# Altium schematic sheet dc_dc_converter.SchDoc
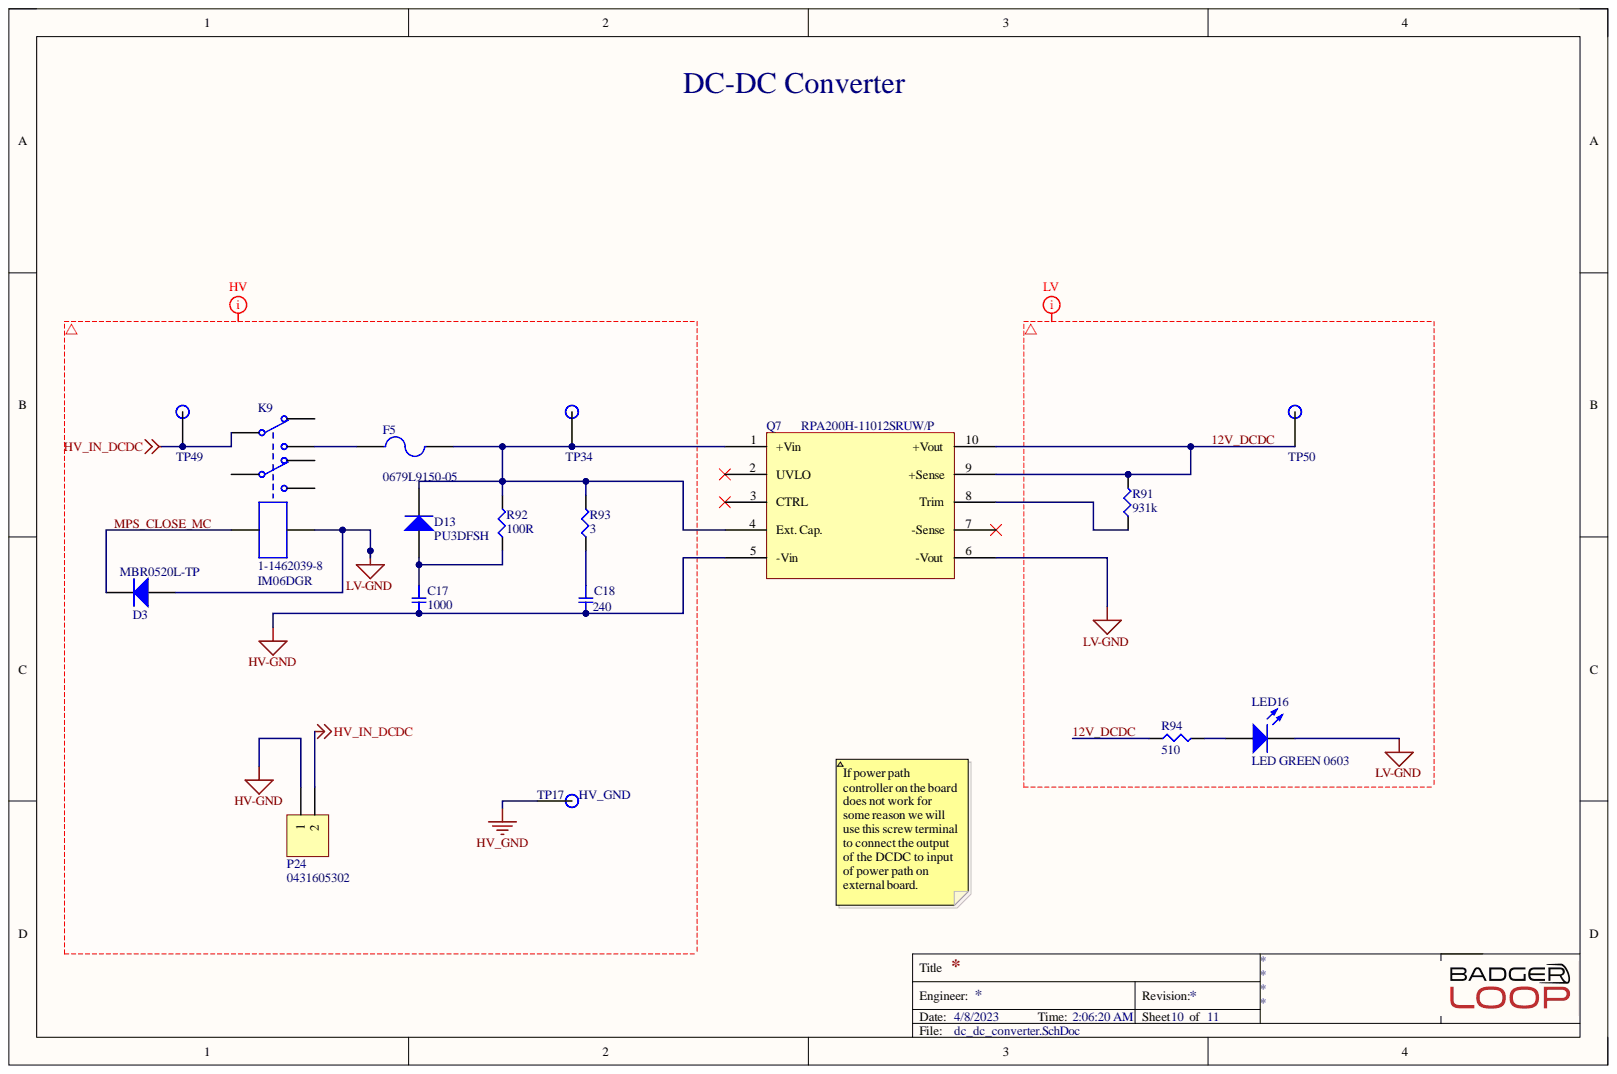
[diagram p0: dc_dc_converter.SchDoc]

## Parts
C17  1000  [ALA7DA241DE600]
C18  ALA7DA241DE600  [ALA7DA241DE600]  (value not drawn)
D3  MBR0520L-TP  [MBR0520LT1G - SOD123]
D13  PU3DFSH  [PU3DFSH]
F5  0679L9150-05  [0679L9150-05 - fuse smd]
K9  1-1462039-8  Manufacturer Part Number: IM06DGR  [1-1462039-8 - relay]
LED16  LED GREEN 0603  Color: GREEN  [LED 0603 GREEN]
P24  0431605302  [CONN HDR 2POS 7.50MM]
Q7  RPA200H-11012SRUW  Manufacturer Part Number: RPA200H-11012SRUW/P  [RPA200H]
R91  931k  [SMD-1206-RES]
R92  100R  [SMD-1206-RES]
R93  3  [SMD-0805-RES]
R94  RMCF1206FT510R  [SMD-1206-RES]  (value not drawn)
TP17  HV_GND  [Test Point]
TP34  TP  [Test Point]
TP49  TP  [Test Point]
TP50  TP  [Test Point]

## Nets
HV-GND: C17.2 C18.2 P24.1 Q7.5(-Vin)
HV_GND: TP17.1
HV_IN_DCDC: K9.3 P24.2 TP49.1
LV-GND: D3.1 K9.8 LED16.2 Q7.6(-Vout)
12V_DCDC: Q7.9(+Sense) Q7.10(+Vout) R91.2 R94.1 TP50.1
MPS_CLOSE_MC: D3.2 K9.1
NetC17_1: C17.1 D13.1 R92.1
NetC18_1: C18.1 R93.1
NetD13_2: D13.2 F5.2 Q7.1(+Vin) Q7.4(Ext._Cap.) R92.2 R93.2 TP34.1
NetF5_1: F5.1 K9.4
NetLED16_1: LED16.1 R94.2
NetQ7_8: Q7.8(Trim) R91.1

Not connected: K9 2 5 6 7; Q7 2(UVLO) 3(CTRL) 7(-Sense)

## Library data of the parts
C17 = ALC70A102DD250: CAP ALUM 1000UF 20% 250V SNAP; Manufacturer: KEMET; Component Kind: Standard; Library Name: capacitors.SchLib; Manufacturer Part Number: ALC70A102DD250; Pricing 1: 1=8.59000, 10=7.63400, 50=6.67940, 100=6.10700, 500=5.24818, 1000=4.86649, 2500=4.83468 (USD); RoHS: Yes; Stock 1: 897; Supplier 1: Digi-Key; Supplier Part Number 1: 399-19601-ND
C18 = ALA7DA241DE600: CAP ALUM 240UF 20% 600V SNAP; Capacitance: 240; Component Kind: Standard; ComponentLink1Description: https://www.digikey.com/en/products/detail/kemet/ALA7DA241DE600/13676315; Library Name: capacitors.SchLib; Manufacturer Part Number: ALA7DA241DE600
D3 = MBR0520L-TP: Rectifier Diode, Schottky, 1 Phase, 1 Element, 0.5A, 20V V(RRM), Silicon; Manufacturer: MCC; Forward Voltage-Max (VF): 300mV; Max Output Current: 500mA; Max Power Dissipation: 410mW; Non-rep Pk Forward Current-Max: 5.5A; Number of Elements: 1; Package Body Material: Plastic; Qualification Status: Not Qualified; Time @ Peak Reflow Temperature-Max (s): 10s; Category: Rectifier Diodes; Component Kind: Standard; ComponentLink1Description: https://datasheet.ciiva.com:443/pdfs/VipMasterIC/IC/MCCC/MCCC-S-A0007607609/MCCC-S-A0007607609-1.pdf?src-supplier=IHS+Markit; ComponentLink1URL: https://datasheet.ciiva.com:443/pdfs/VipMasterIC/IC/MCCC/MCCC-S-A0007607609/MCCC-S-A0007607609-1.pdf?src-supplier=IHS+Markit; ComponentLink2Description: https://datasheet.ciiva.com/24106/mbr0520lmbr0540lsod-123-276512-24106653.pdf?src-supplier=Mouser; ComponentLink2URL: https://datasheet.ciiva.com/24106/mbr0520lmbr0540lsod-123-276512-24106653.pdf?src-supplier=Mouser; ComponentLink3Description: https://datasheet.ciiva.com/6381/mbr0520lmbr0540lsod-123-6381109.pdf?src-supplier=Digi-Key; ComponentLink3URL: https://datasheet.ciiva.com/6381/mbr0520lmbr0540lsod-123-6381109.pdf?src-supplier=Digi-Key; Diode Element Material: SILICON; Diode Type: RECTIFIER; JESD-30 Code: R-PDSO-G2; JESD-609 Code: e3; Library Name: misc.SchLib; Manufacturer Part Number: MBR0520L-TP; Max Operating Temperature: 125°C; Moisture Sensitivity Level: 1; Number of Pins: 2; Package Shape: Rectangular; Package Style: SMALL OUTLINEMeter; Peak Reflow Temperature (Cel): 260°C; Pricing 1: 1=0.52000, 10=0.38700, 100=0.21930, 500=0.14520, 1000=0.11132 (USD); REACH SVHC: Yes; Rep Pk Reverse Voltage-Max: 20V; RoHS: Yes; RoHS Compliant: Yes; Stock 1: 75810; Supplier 1: Digi-Key; Supplier Part Number 1: MBR0520L-TPMSCT-ND; Surface Mount: Yes; Technology: SCHOTTKY; Terminal Finish: Tin; Terminal Form: Gull Wing; Terminal Position: DUAL
D13 = PU3DFSH: Diode Standard 200 V 3A (DC) Surface Mount SOD-128; Component Kind: Standard; ComponentLink1Description: Digikey Link; ComponentLink1URL: https://www.digikey.com/en/products/detail/taiwan-semiconductor-corporation/PU3DFSH/15517034; Library Name: misc.SchLib
F5 = 0679L9150-05: Manufacturer: Bel; Category: Fuses; Component Kind: Standard; ComponentLink1Description: https://datasheet.ciiva.com:443/pdfs/VipMasterIC/IC/BELF/BELF-S-A0001301144/BELF-S-A0001301144-1.pdf?src-supplier=IHS+Markit; ComponentLink1URL: https://datasheet.ciiva.com:443/pdfs/VipMasterIC/IC/BELF/BELF-S-A0001301144/BELF-S-A0001301144-1.pdf?src-supplier=IHS+Markit; ComponentLink2Description: http://www.belfuse.com/resources/CircuitProtection/datasheets/0679L%20Sep2016.pdf?src-supplier=Digi-Key; ComponentLink2URL: http://www.belfuse.com/resources/CircuitProtection/datasheets/0679L%20Sep2016.pdf?src-supplier=Digi-Key; ComponentLink3Description: https://www.belfuse.com/resources/CircuitProtection/datasheets/0679L%20Sep2016.pdf?src-supplier=Digi-Key; ComponentLink3URL: https://www.belfuse.com/resources/CircuitProtection/datasheets/0679L%20Sep2016.pdf?src-supplier=Digi-Key; Library Name: misc.SchLib; Manufacturer Part Number: 0679L9150-05; Pricing 1: 1=0.44000, 5=0.42600, 10=0.41400, 50=0.34800, 100=0.28890, 250=0.26260, 500=0.22320, 1000=0.19695 (USD); RoHS: Yes; Stock 1: 24804; Supplier 1: Digi-Key; Supplier Part Number 1: 507-2000-1-ND
K9 = CMP-004-00046-1: Zener Diode, 62V V(Z), 5%, 5W, Silicon, Unidirectional; Manufacturer: TE Connectivity; Body Breadth: 6inch; Body Height: 5.65mm; Body Length or Diameter: 10mm; Coil Operate Voltage(DC): 9V; Coil Power: 140mW; Coil Release Voltage(DC): 1.2V; Coil Resistance: 1.029kR; Coil Voltage-Nom: 12V; Coil/Input Supply Type: DC; Contact AC Max Power Rating R Load: 62.5VA@250VAC; Contact AC Max Rating R Load: 5A@250VAC; Contact Current(AC)-Max: 5A; Contact DC Max Power Rating R Load: 60W@220VDC; Contact DC Max Rating R Load: 5A@220VDC; Contact Resistance: 50mR; Contact Voltage(AC)-Max: 250V; Contact Voltage(DC)-Max: 220V; Contact/Output Supply Type: AC/DC; Dielectric Strength Between Coil and Contacts: 1500Vrms; Dielectric Strength Between Open Contacts: 750Vrms; Dynamic Impedance-Max: 42R; End Contact Material: Silver; End Contact Plating: Gold; Input Switching Control Type: Random; Insulation Resistance: 1GR; Number of Elements: 1; Operate Time: 3ms; Package Body Material: Plastic; Qualification Status: Not Qualified; Reference Standard: UL; Relay Form: 2 FORM C; Relay Function: DPDT; Sealing: HERMETICAL; Surface Mount: No; Test Current: 20mA; Time @ Peak Reflow Temperature-Max (s): 40s; Case/Package: Isolated; Category: Power Relays; Component Kind: Standard; ComponentLink1Description: https://datasheet.ciiva.com:443/pdfs/VipMasterIC/IC/TYEL/TYELS75403/TYELS75403-1.pdf?src-supplier=IHS+Markit; ComponentLink1URL: https://datasheet.ciiva.com:443/pdfs/VipMasterIC/IC/TYEL/TYELS75403/TYELS75403-1.pdf?src-supplier=IHS+Markit; ComponentLink2Description: https://datasheet.ciiva.com/1662/13778-1662593.pdf?src-supplier=Newark; ComponentLink2URL: https://datasheet.ciiva.com/1662/13778-1662593.pdf?src-supplier=Newark; ComponentLink3Description: https://datasheet.ciiva.com/1525/1717896-1525047.pdf?src-supplier=Element14; ComponentLink3URL: https://datasheet.ciiva.com/1525/1717896-1525047.pdf?src-supplier=Element14; ComponentLink4Description: https://datasheet.ciiva.com/1612/1717896-1612285.pdf?src-supplier=Farnell; ComponentLink4URL: https://datasheet.ciiva.com/1612/1717896-1612285.pdf?src-supplier=Farnell; ComponentLink5Description: https://datasheet.ciiva.com/30159/customerdrawing1462037-4a10-30159339.pdf?src-supplier=Onlinecomponents.com; ComponentLink5URL: https://datasheet.ciiva.com/30159/customerdrawing1462037-4a10-30159339.pdf?src-supplier=Onlinecomponents.com; ComponentLink6Description: https://datasheet.ciiva.com/22697/1717896-22697038.pdf?src-supplier=Element14; ComponentLink6URL: https://datasheet.ciiva.com/22697/1717896-22697038.pdf?src-supplier=Element14; ComponentLink7Description: https://datasheet.ciiva.com:443/pdfs/VipMasterIC/IC/ONSM/ONSMS36970/ONSMS36970-1.pdf?src-supplier=IHS+Markit; ComponentLink7URL: https://datasheet.ciiva.com:443/pdfs/VipMasterIC/IC/ONSM/ONSMS36970/ONSMS36970-1.pdf?src-supplier=IHS+Markit; ComponentLink8Description: https://datasheet.ciiva.com/895/1n5333b-d-895434.pdf?src-supplier=Digi-Key; ComponentLink8URL: https://datasheet.ciiva.com/895/1n5333b-d-895434.pdf?src-supplier=Digi-Key; ComponentLink9Description: https://datasheet.ciiva.com/26841/getdatasheetpartid-498049-26841989.pdf?src-supplier=Verical; ComponentLink9URL: https://datasheet.ciiva.com/26841/getdatasheetpartid-498049-26841989.pdf?src-supplier=Verical; ComponentLink10Description: https://datasheet.ciiva.com/8026/56785-8026956.pdf?src-supplier=Element14; ComponentLink10URL: https://datasheet.ciiva.com/8026/56785-8026956.pdf?src-supplier=Element14; ComponentLink11Description: https://datasheet.ciiva.com/16311/1n5333b-d-16311951.pdf?src-supplier=Future+Electronics; ComponentLink11URL: https://datasheet.ciiva.com/16311/1n5333b-d-16311951.pdf?src-supplier=Future+Electronics; Contact Current(DC)-Max: 5A; Design Item ID: 1-1462039-8; Diode Element Material: SILICON; Diode Type: ZENER; JESD-30 Code: O-PALF-W2; JESD-609 Code: e3; Library Name: Altium Concord Pro on A365; Max Operating Temperature: 200°C; Max Power Dissipation: 5W; Min Operating Temperature: -65°C; Package Shape: Round; Package Style: LONG FORMMeter; Packaging: Tape and Reel; Peak Reflow Temperature (Cel): 260°C; Pins: 8; Polarity: Unidirectional; Pricing 1: 1=5.59000, 10=5.24500, 25=4.66200, 50=4.42880, 100=4.19580, 250=3.72960, 500=3.49650 (USD); Pricing 2: 1=0.29200, 10=0.26520, 100=0.19400, 500=0.18170, 1000=0.13380, 2000=0.12160, 5000=0.12040, 10000=0.11910 (USD); REACH SVHC: No SVHC; Reference Voltage-Nom: 62V; RoHS: No; RoHS Compliant: No; Source: misc.SchLib; Stock 1: 35148; Stock 2: 6890; Technology: ZENER; Terminal Finish: Tin; Terminal Form: Wire; Terminal Position: AXIAL; Termination: Solder; Voltage Tol-Max: 5%; Weight: 0.75g
LED16 = LED GREEN 0603: Manufacturer: Lite-On Inc.; Manufacturer P/N: LTST-C190KGKT; Component Kind: Standard; Digikey P/N: 160-1435-1-ND; Library Name: misc.SchLib; Package / Case: 0603
P24 = 0431605302: Conn Power HDR 2 POS 7.49mm Solder RA Thru-Hole 4 Terminal 1 Port Sabre™ Tray; Manufacturer: Molex; Contact Resistance: 30mR; Current Rating: 18A; Number of Contacts: 2; Number of Ports: 1; Voltage Rating: 600V; Color: Black; Component Kind: Standard; ComponentLink1Description: https://www.verical.com/server-webapp/html/getDatasheet?partID=198412&src-supplier=Verical; ComponentLink1URL: https://www.verical.com/server-webapp/html/getDatasheet?partID=198412&src-supplier=Verical; ComponentLink2Description: http://components.arrow.com/part/dataview/2942820S6220968N3303?src-supplier=Arrow; ComponentLink2URL: http://components.arrow.com/part/dataview/2942820S6220968N3303?src-supplier=Arrow; ComponentLink3Description: http://www.molex.com/pdm_docs/ps/PSX-43335-9999.pdf?src-supplier=Digi-Key; ComponentLink3URL: http://www.molex.com/pdm_docs/ps/PSX-43335-9999.pdf?src-supplier=Digi-Key; Contact Material: Brass; Contact Plating: Tin,Copper; Depth: 19.79mm; Fastening Type: Ramp; Housing Color: Black; Housing Material: Nylon; Insulation Material: Nylon; Length: 21.08mm; Library Name: connector.SchLib; Manufacturer Part Number: 0431605302; Max Operating Temperature: 75°C; Min Operating Temperature: -40°C; Mount: Through Hole; Number of Positions: 2; Number of Rows: 1; Number of Terminals: 4; Orientation: Right Angle; Package Quantity: 525; Packaging: Tray; Pitch: 7.49mm; Stock 1: 0; Supplier 1: Digi-Key; Supplier Part Number 1: WM13983-ND; Termination: Solder
Q7 = RPA200H-11012SRUW: DC DC CONVERTER 12V 200W; Manufacturer: Recom; Analog IC - Other Type: DC-DC REGULATED POWER SUPPLY MODULE; Category: Board Mount Modules; Component Kind: Standard; ComponentLink1Description: https://datasheet.ciiva.com:443/pdfs/VipMasterIC/IC/RECG/RECG-S-A0009169714/RECG-S-A0009169714-1.pdf?src-supplier=IHS+Markit; ComponentLink1URL: https://datasheet.ciiva.com:443/pdfs/VipMasterIC/IC/RECG/RECG-S-A0009169714/RECG-S-A0009169714-1.pdf?src-supplier=IHS+Markit; ComponentLink2Description: https://www.recom-power.com/pdf/Powerline_DC-DC/RPA200H-RUW.pdf?src-supplier=Digi-Key; ComponentLink2URL: https://www.recom-power.com/pdf/Powerline_DC-DC/RPA200H-RUW.pdf?src-supplier=Digi-Key; Library Name: ic.SchLib; Pricing 1: 1=233.71000, 10=229.93000, 50=229.93000, 100=229.93000, 1000=229.93000, 10000=229.93000 (USD); REACH SVHC: Yes; RoHS: Yes; RoHS Compliant: Yes; Stock 1: 1; Supplier 1: Mouser; Supplier Part Number 1: 919-RPA200H11012SRUP
R91 = ERJ-8ENF9313V: Fixed Resistor, Metal Glaze/thick Film, 0.5W, 470ohm, 200V, 1% +/-Tol, 100ppm/Cel, Surface Mount, 1206; Manufacturer: Panasonic Electronic Components; Manufacturer Part Number: RCC1206470RFKEA; @ qty: 1500; Factory Stock: 0; Minimum Quantity: 1; Power (Watts): 0.25W, 1/4W; Temperature Coefficient: ±100ppm/°C; Unit Price (USD): 0.00933; Component Kind: Standard; Composition: Thick Film; Datasheets: http://industrial.panasonic.com/www-cgi/jvcr13pz.cgi?E+PZ+3+AOA0002+ERJ8ENF9313V+7+WW; Digi-Key Part Number: P931KFCT-ND; Failure Rate: -; Features: Automotive AEC-Q200; Height - Seated (Max): 0.028" (0.70mm); Image: //media.digikey.com/Renders/Panasonic%20Renders/ERJ-1206%20Pkg.jpg; Library Name: resistors.SchLib; Number of Terminations: 2; Operating Temperature: -55°C ~ 155°C; Package / Case: 1206 (3216 Metric); Packaging: Cut Tape (CT); Part Number: ERJ-8ENF9313V; Part Status: Active; Pricing 1: 1=0.32000, 10=0.27600, 50=0.27600, 100=0.10800, 250=0.10700, 1000=0.04500, 5000=0.04300, 10000=0.04300 (USD); Quantity Available: 20839; RoHS: Yes; Series: ERJ; Size / Dimension: 0.126" L x 0.063" W (3.20mm x 1.60mm); Stock 1: 13044; Supplier 1: Mouser; Supplier Device Package: 1206; Supplier Part Number 1: 71-RCC1206470RFKEA; Tolerance: ±1%; Value: 931k
R92 = HRG3216P-1000-D-T1: Fixed Resistor, Thin Film, 1W, 100ohm, 200V, 0.5% +/-Tol, 25ppm/Cel, Surface Mount, 1206; Manufacturer: Susumu; Additional Feature: ANTI-SULFUR; Package Height: 0.4mm; Package Length: 3.2mm; Package Width: 1.6mm; Rated Temperature: 70°C; Reference Standard: AEC-Q200; Temperature Coefficient: 25ppm/°C; Category: Chip SMD Resistors; Component Kind: Standard; ComponentLink1Description: https://datasheet.ciiva.com:443/pdfs/VipMasterIC/IC/SUSU/SUSU-S-A0004582570/SUSU-S-A0004582570-1.pdf?src-supplier=IHS+Markit; ComponentLink1URL: https://datasheet.ciiva.com:443/pdfs/VipMasterIC/IC/SUSU/SUSU-S-A0004582570/SUSU-S-A0004582570-1.pdf?src-supplier=IHS+Markit; ComponentLink2Description: http://www.farnell.com/datasheets/2643250.pdf?src-supplier=Farnell; ComponentLink2URL: http://www.farnell.com/datasheets/2643250.pdf?src-supplier=Farnell; ComponentLink3Description: http://www.susumu.co.jp/common/pdf/n_catalog_partition24_en.pdf?src-supplier=Digi-Key; ComponentLink3URL: http://www.susumu.co.jp/common/pdf/n_catalog_partition24_en.pdf?src-supplier=Digi-Key; ComponentLink4Description: http://www.farnell.com/datasheets/2643250.pdf?src-supplier=Newark; ComponentLink4URL: http://www.farnell.com/datasheets/2643250.pdf?src-supplier=Newark; ComponentLink5Description: http://www.farnell.com/datasheets/2643250.pdf?src-supplier=Element14; ComponentLink5URL: http://www.farnell.com/datasheets/2643250.pdf?src-supplier=Element14; Construction: Rectangular; Library Name: resistors.SchLib; Manufacturer Part Number: HRG3216P-1000-D-T1; Max Operating Temperature: 155°C; Min Operating Temperature: -55°C; Mount: Surface Mount; Number of Pins: 2; Package Style: SMTMeter; Packaging: Tape and Reel; Pricing 1: 1=0.88000, 10=0.76200, 100=0.53130 (USD); Rated Power Dissipation (P): 1W; REACH SVHC: Yes; Resistance: 100R; Resistor Type: FIXED RESISTOR; RoHS: Yes; RoHS Compliant: Yes; Size Code: 1206; Stock 1: 24654; Supplier 1: Digi-Key; Supplier Part Number 1: 408-1865-1-ND; Technology: THIN FILM; Termination: Wraparound; Tolerance: 0.5%; Working Voltage: 200V
R93 = PCAN0805K3R00FST5: Fixed Resistor; Manufacturer: Vishay Dale Thin Film; Manufacturer Series: PCAN; Category: Chip SMD Resistors; Component Kind: Standard; ComponentLink1Description: https://datasheet.ciiva.com:443/pdfs/VipMasterIC/IC/VISH/VISH-S-A0001545402/VISH-S-A0001545402-1.pdf?src-supplier=IHS+Markit; ComponentLink1URL: https://datasheet.ciiva.com:443/pdfs/VipMasterIC/IC/VISH/VISH-S-A0001545402/VISH-S-A0001545402-1.pdf?src-supplier=IHS+Markit; ComponentLink2Description: http://www.vishay.com/docs/60125/pcan.pdf?src-supplier=Digi-Key; ComponentLink2URL: http://www.vishay.com/docs/60125/pcan.pdf?src-supplier=Digi-Key; ComponentLink3Description: http://www.vishay.com/docs/49563/_pcan_vmn-pt0416.pdf?src-supplier=Digi-Key; ComponentLink3URL: http://www.vishay.com/docs/49563/_pcan_vmn-pt0416.pdf?src-supplier=Digi-Key; Library Name: resistors.SchLib; Manufacturer Part Number: PCAN0805K3R00FST5; Number of Pins: 2; Pricing 1: 500=1.51200, 1000=1.31040 (USD); REACH SVHC: No SVHC; Resistor Type: FIXED RESISTOR; RoHS: Yes; RoHS Compliant: Yes; Stock 1: 1500; Supplier 1: Digi-Key; Supplier Part Number 1: 764-1232-2-ND
R94 = RMCF1206FT510R: Fixed Resistor, Metal Glaze/thick Film, 0.25W, 510ohm, 200V, 1% +/-Tol, 100ppm/Cel, Surface Mount, 1206; Manufacturer: Stackpole Electronics; Package Height: 0.55mm; Package Length: 3.2mm; Package Width: 1.6mm; Rated Power Dissipation (P): 250mW; Rated Temperature: 70°C; Reference Standard: AEC-Q200; Resistance: 510; Temperature Coefficient: 100ppm/°C; Category: Chip SMD Resistors; Component Kind: Standard; ComponentLink1Description: https://datasheet.ciiva.com:443/pdfs/VipMasterIC/IC/SEIE/SEIE-S-A0009541969/SEIE-S-A0009541969-1.pdf?src-supplier=IHS+Markit; ComponentLink1URL: https://datasheet.ciiva.com:443/pdfs/VipMasterIC/IC/SEIE/SEIE-S-A0009541969/SEIE-S-A0009541969-1.pdf?src-supplier=IHS+Markit; ComponentLink2Description: http://components.arrow.com/part/dataview/40948710S9551678N1174?src-supplier=Arrow+Electronics; ComponentLink2URL: http://components.arrow.com/part/dataview/40948710S9551678N1174?src-supplier=Arrow+Electronics; ComponentLink3Description: https://www.seielect.com/Catalog/SEI-RMCF_RMCP.pdf?src-supplier=Digi-Key; ComponentLink3URL: https://www.seielect.com/Catalog/SEI-RMCF_RMCP.pdf?src-supplier=Digi-Key; ComponentLink4Description: https://www.seielect.com/catalog/SEI-Packaging.pdf?src-supplier=Digi-Key; ComponentLink4URL: https://www.seielect.com/catalog/SEI-Packaging.pdf?src-supplier=Digi-Key; ComponentLink5Description: https://www.seielect.com/catalog/SEI-RMCF_RMCP.pdf?src-supplier=Avnet; ComponentLink5URL: https://www.seielect.com/catalog/SEI-RMCF_RMCP.pdf?src-supplier=Avnet; Construction: Rectangular; JESD-609 Code: e3; Library Name: resistors.SchLib; Manufacturer Part Number: RMCF1206FT510R; Max Operating Temperature: 155°C; Min Operating Temperature: -55°C; Mount: Surface Mount; Number of Pins: 2; Package Shape: Rectangular; Package Style: SMTMeter; Packaging: Tape and Reel; Pricing 1: 1=0.10000, 10=0.03600, 100=0.01460, 1000=0.00655, 2500=0.00569 (USD); REACH SVHC: Yes; Resistor Type: FIXED RESISTOR; RoHS: Yes; RoHS Compliant: Yes; Size Code: 1206; Stock 1: 51; Supplier 1: Digi-Key; Supplier Part Number 1: RMCF1206FT510RCT-ND; Technology: METAL GLAZE/THICK FILM; Terminal Finish: Nickel; Termination: Wraparound; Tolerance: 1%; Working Voltage: 200V
TP17 = CMP-039-000000-1: SMD TEST POINT; Component Kind: Standard; Design Item ID: TP; Library Name: Altium Concord Pro on A365; Source: misc.SchLib
TP34 TP49 TP50 = TP: SMD TEST POINT; Component Kind: Standard; Library Name: misc.SchLib
